# Altium schematic sheet CAN.SchDoc(CAN)
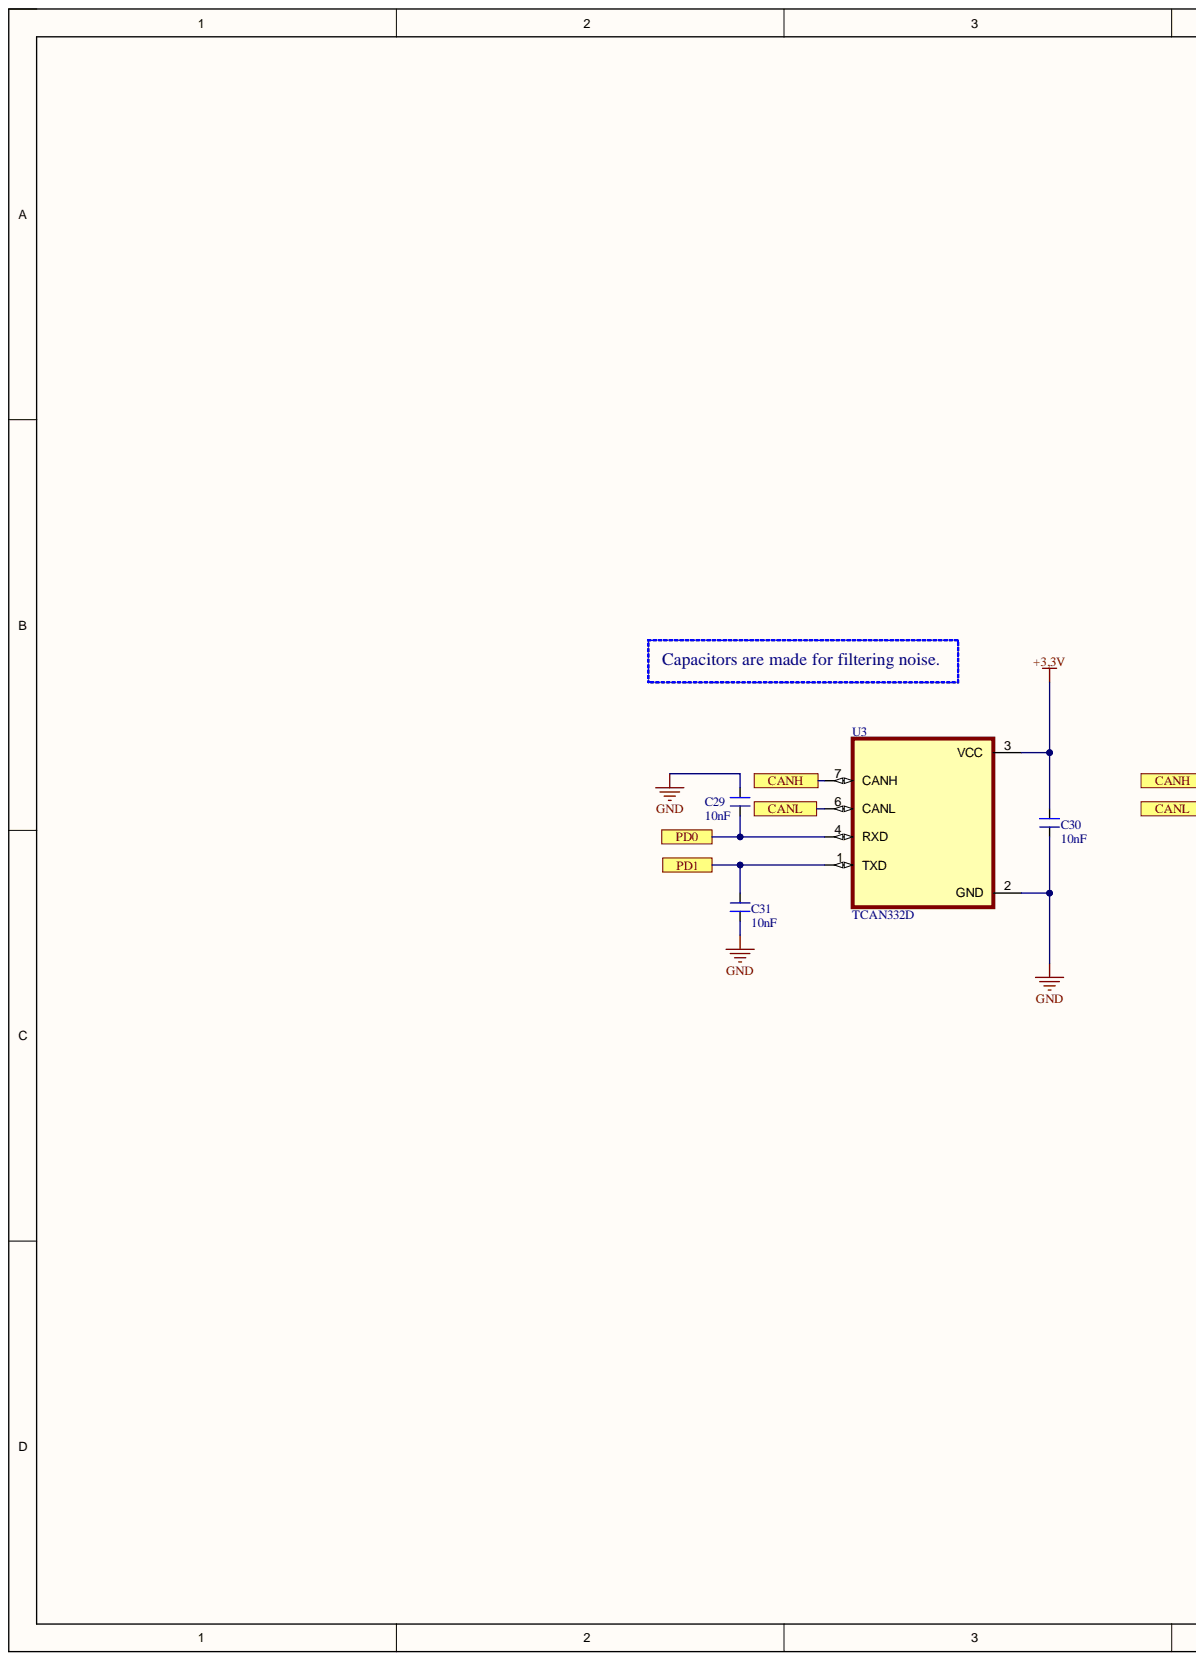
[diagram p0: CAN.SchDoc, left]
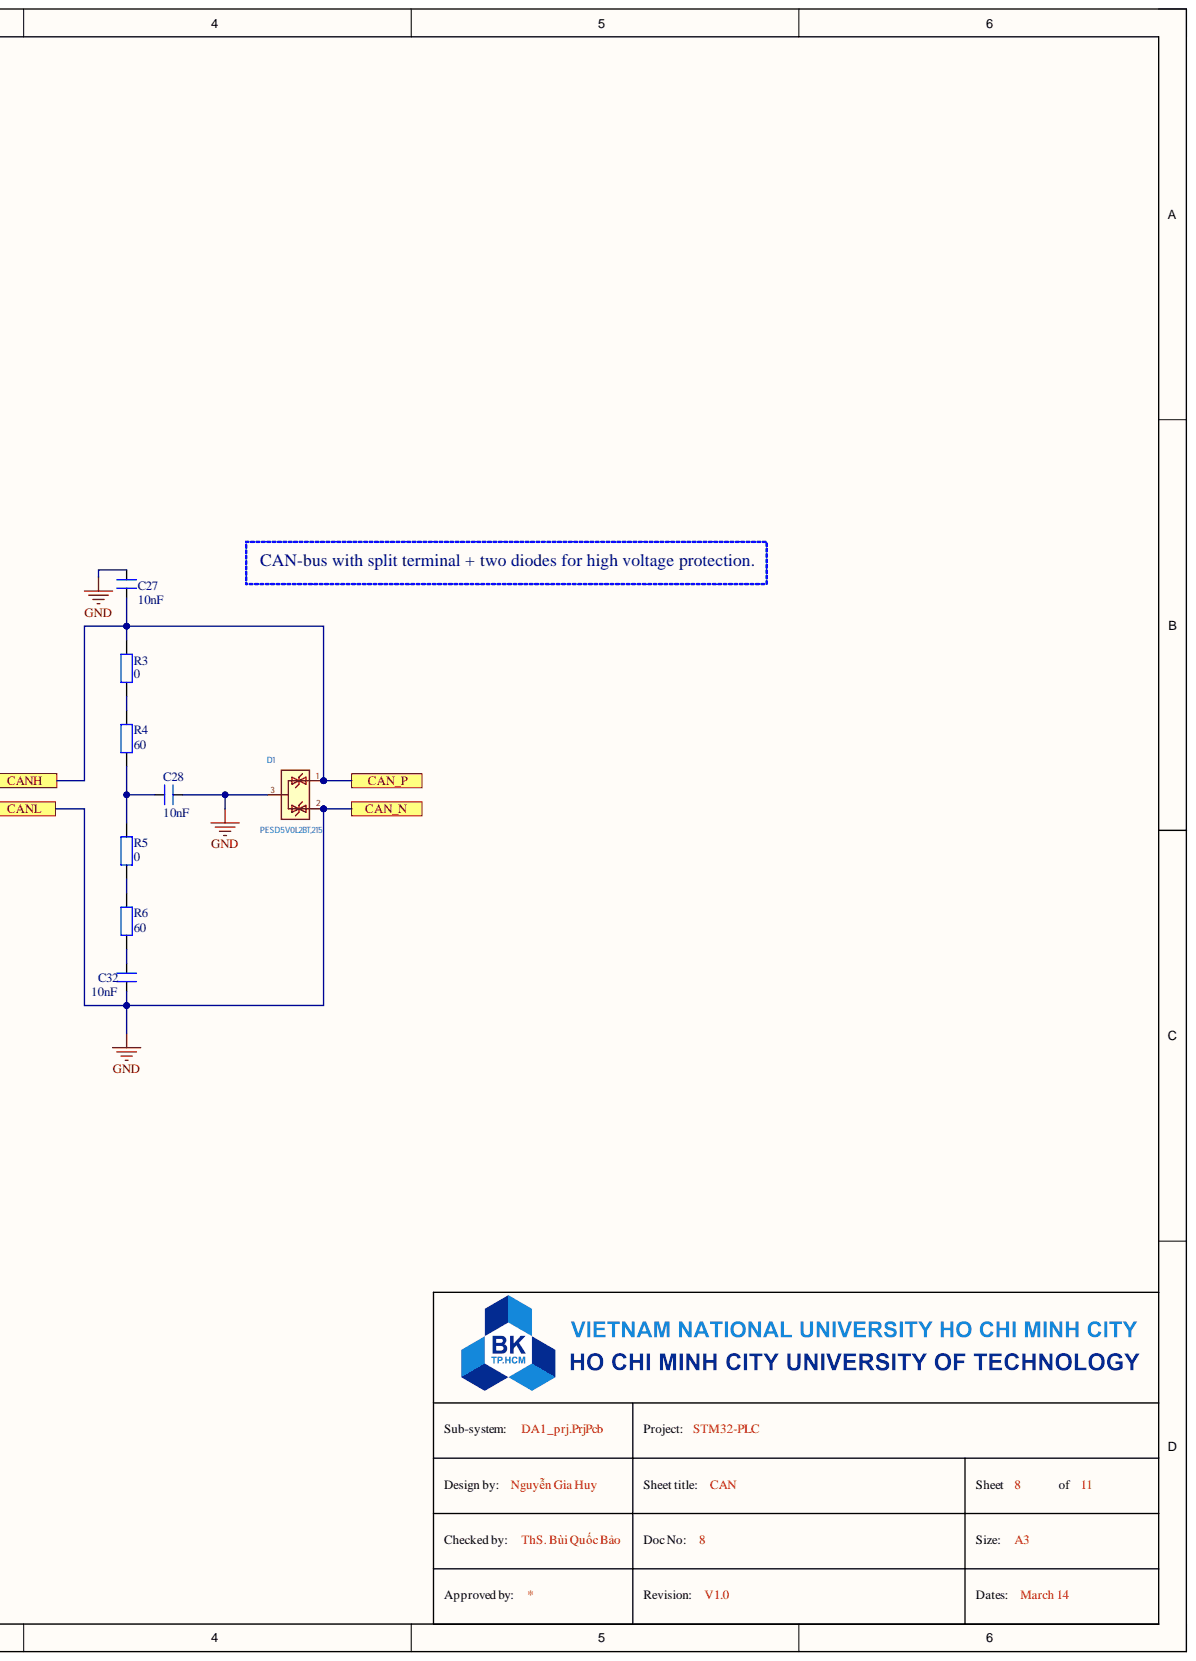
[diagram p1: CAN.SchDoc, right]

## Parts
C27  10nF  [FP-C0603C-CF-IPC_A]
C28  10nF  [FP-C0603C-CF-IPC_A]
C29  10nF  [FP-C0603C-CF-IPC_A]
C30  10nF  [FP-C0603C-CF-IPC_A]
C31  10nF  [FP-C0603C-CF-IPC_A]
C32  10nF  [FP-C0603C-CF-IPC_A]
D1  PESD5V0L2BT,215  [Package_TO_SOT_SMD:SOT-23]
R3  0  [tro smd 0603]
R4  60  [tro smd 0603]
R5  0  [tro smd 0603]
R6  60  [tro smd 0603]
U3  TCAN332D  [SOIC127P599X175-8N]

## Ports
CAN_N, CAN_P, CANH, CANL, PD0, PD1

## Nets
+3,3V: C30.2 U3.3(VCC)
CANH: C27.2 D1.1 R3.2 U3.7(CANH) ; port CANH, port CAN_P
GND: C27.1 C28.1 C29.2 C30.1 C31.1 C32.1 D1.2 D1.3 U3.2(GND) U3.6(CANL) ; port CANL, port CAN_N
PD0: C29.1 U3.4(RXD) ; port PD0
PD1: C31.2 U3.1(TXD) ; port PD1
NetC28_2: C28.2 R4.1 R5.2
NetC32_2: C32.2 R6.1
NetR3_1: R3.1 R4.2
NetR5_1: R5.1 R6.2

## Library data of the parts
C27-C32 = CN-SEMI-DIP: Capacitor (Semiconductor SIM Model); Value: 104; Component Kind: Standard; LatestRevisionDate: 17-Jul-2002; LatestRevisionNote: Re-released for DXP Platform.; Library Name: LIBRARY.IntLib; PackageReference: C1206; Publisher: Altium Limited
D1 = NUP2105L: Component Kind: Standard; Library Name: Power_Protection; Mouser Eletronics: 755-MMBZ6V8ALFHT116; Value: PESD5V0L2BT,215
R3-R6 = Tro 0603 1% 10k: RES; Chung_Loai: Dán; Don_Vi: Con; Ma_Hang: MH006333; So_Chan: 2; Ten_Linh_Kien: Trở 0603 1% 10k; Component Kind: Standard; Library Name: AUSB.SchLib
U3 = TCAN332D: 3.3-V CAN Transceivers; MF: Texas Instruments; Check_prices: https://www.snapeda.com/parts/TCAN332D/Texas+Instruments/view-part/?ref=eda; Component Kind: Standard; Library Name: TCAN332D.IntLib; MP: TCAN332D; Package: SOIC-8 Texas Instruments; SnapEDA_Link: https://www.snapeda.com/parts/TCAN332D/Texas+Instruments/view-part/?ref=snap
